# Altium schematic sheet 04_NOTECARD-CONNECTION.SchDoc
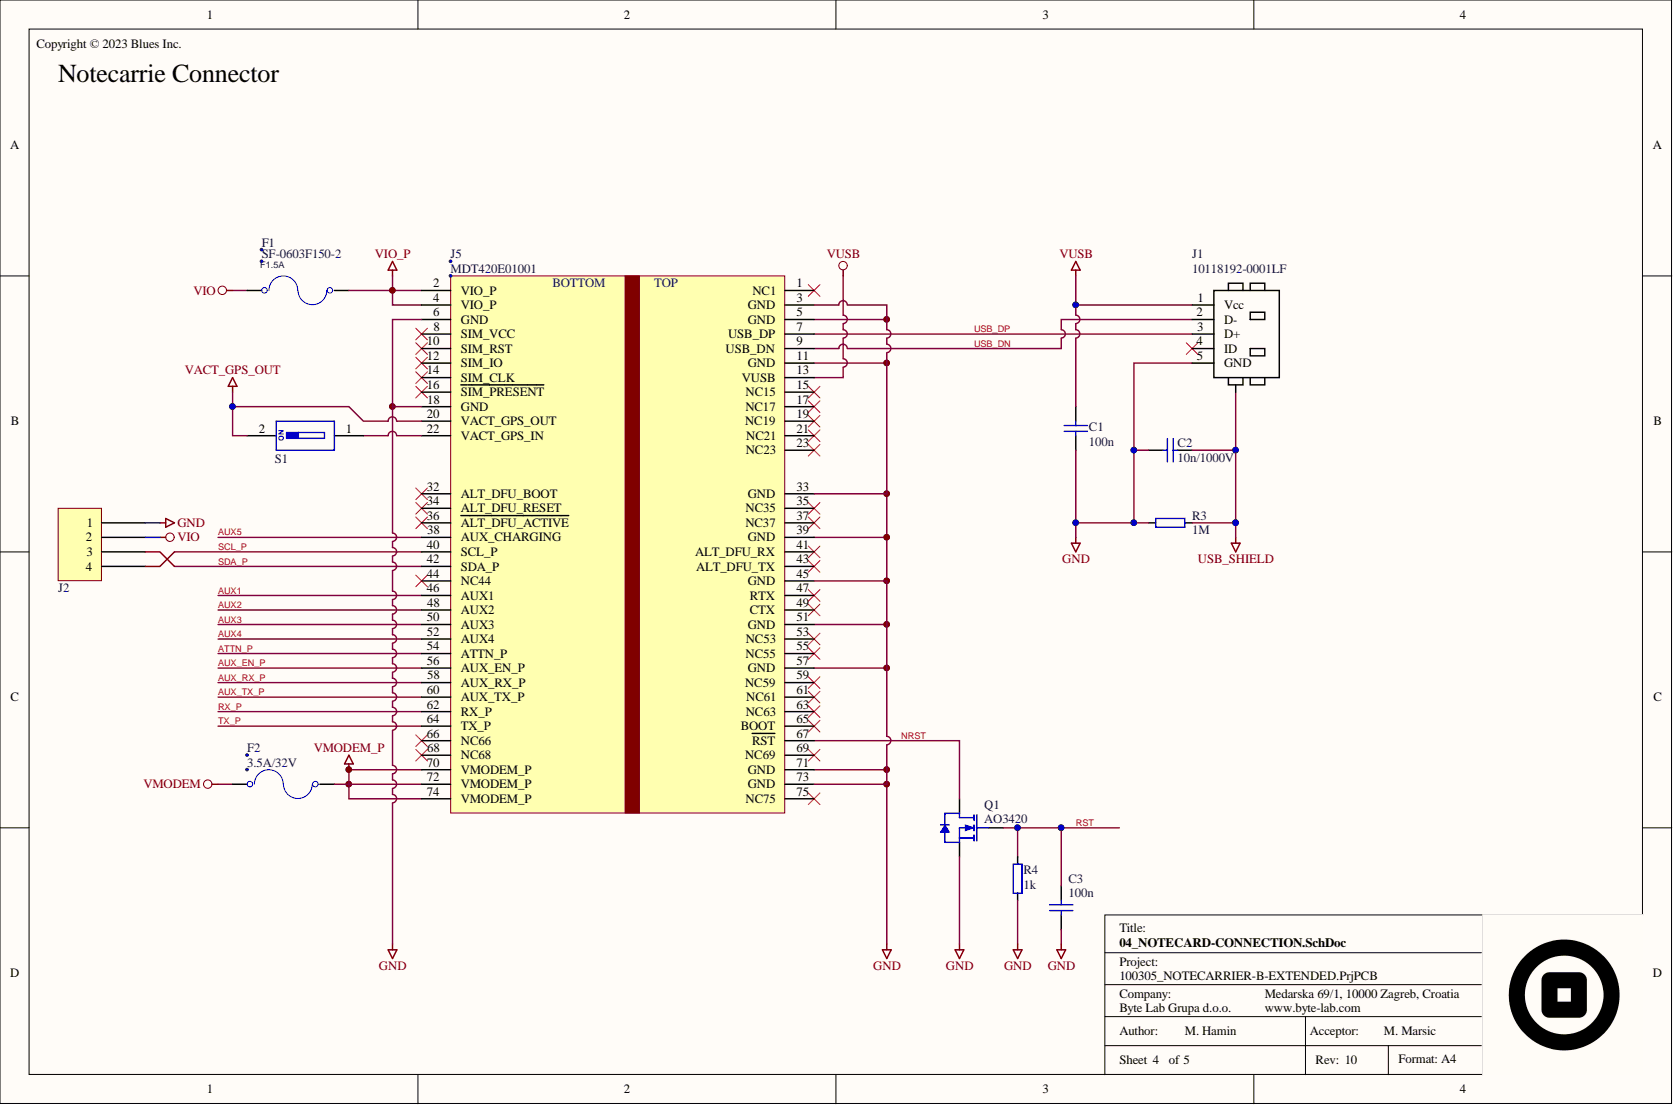
[diagram p0: 04_NOTECARD-CONNECTION.SchDoc]

## Parts
C1  100n  [C-0402]
C2  10n/1000V  [C-1206]
C3  100n  [C-0402]
F1  SF-0603F150-2  [FS-0603]
F2  3.5A/32V  [FS-0603]
J1  10118192-0001LF  [Conn_USB_B_Micro_-_10118192-0001LF]
J2  SM04B-SRSS-TB(LF)(SN)  [JST SM04B-SRSS-TB]  (value not drawn)
J5  MDT420E01001  [J-75-0050-MOS-M2]
Q1  AO3420  [SOT-23]
R3  1M  [R-1206]
R4  1k  [R-0402]
S1  CHS-01TB  [SWITCH DIP 1POS SMD CHS-01TB]  (value not drawn)

## Nets
GND: C1.2 C2.2 C3.1 J1.5(GND) J2.1 J5.3(GND) J5.5(GND) J5.6(GND) J5.11(GND) J5.18(GND) J5.33(GND) J5.39(GND) J5.45(GND) J5.51(GND) J5.57(GND) J5.71(GND) J5.73(GND) Q1.2 R3.2 R4.1
USB_SHIELD: C2.1 J1.M R3.1
VACT_GPS_OUT: J5.20(VACT_GPS_OUT) S1.2
VIO: F1.1 J2.2
VIO_P: F1.2 J5.2(VIO_P) J5.4(VIO_P)
VMODEM: F2.1
VMODEM_P: F2.2 J5.70(VMODEM_P) J5.72(VMODEM_P) J5.74(VMODEM_P)
VUSB: C1.1 J1.1(Vcc) J5.13(VUSB)
ATTN_P: J5.54(ATTN_P)
AUX1: J5.46(AUX1)
AUX2: J5.48(AUX2)
AUX3: J5.50(AUX3)
AUX4: J5.52(AUX4)
AUX5: J5.38(AUX_CHARGING)
AUX_EN_P: J5.56(AUX_EN_P)
AUX_RX_P: J5.58(AUX_RX_P)
AUX_TX_P: J5.60(AUX_TX_P)
NRST: J5.67(RST) Q1.3
RST: C3.2 Q1.1 R4.2
RX_P: J5.62(RX_P)
SCL_P: J2.4 J5.40(SCL_P)
SDA_P: J2.3 J5.42(SDA_P)
TX_P: J5.64(TX_P)
USB_DN: J1.2(D-) J5.9(USB_DN)
USB_DP: J1.3(D+) J5.7(USB_DP)
NetJ5_22: J5.22(VACT_GPS_IN) S1.1

Not connected: J1 4(ID); J5 1(NC1) 8(SIM_VCC) 10(SIM_RST) 12(SIM_IO) 14(SIM_CLK) 15(NC15) 16(SIM_PRESENT) 17(NC17) 19(NC19) 21(NC21) 23(NC23) 32(ALT_DFU_BOOT) 34(ALT_DFU_RESET) 35(NC35) 36(ALT_DFU_ACTIVE) 37(NC37) 41(ALT_DFU_RX) 43(ALT_DFU_TX) 44(NC44) 47(RTX) 49(CTX) 53(NC53) 55(NC55) 59(NC59) 61(NC61) 63(NC63) 65(BOOT) 66(NC66) 68(NC68) 69(NC69) 75(NC75)

## Library data of the parts
C1 C3 = C0402-100n-16V-X7R: Capacitor ceramic 100n ±10% X7R 16V 0402; BL Part Number: 2000-908; Checked_By: Dodig; Drawn_By: Puskar; Component Kind: Standard; DateModified: 27-08-2013; Library Name: BL_Passive.SchLib; Manufacturer: N/A; Manufacturer Part Number: N/A; Simulation: CAP; Supplier 1: Digi-Key; Supplier Part Number 1: 490-3261-1-ND
C2 = C1206-10n-1000V-X7R - CS1206KKX7RCBB103: Capacitor ceramic 10n ±10% X7R 1000V 1206; BL Part Number: 2007-449; Manufacturer: YAGEO; Drawn_By: Hamin; Component Kind: Standard; DateModified: 03-05-2023; Library Name: BL_Passive.SchLib; Manufacturer Part Number: CS1206KKX7RCBB103; Supplier 1: Digi-Key; Supplier Part Number 1: 311-3578-1-ND
F1 = RAPIDO - SF-0603F150-2-1.5A/32V: FUSIBILE RAPIDO 1.5A 32V 0603 SF-0603F150-2 BOURNS SMT; BL Part Number: 2007-468; Manufacturer: Bourns Inc.; Drawn_By: Blues Wireless; Component Kind: Standard; Library Name: n21-p2.SchLib; Manufacturer Part Number: SF-0603F150-2; Supplier 1: Digi-Key; Supplier Part Number 1: SF-0603F150-2CT-ND
F2 = RAPIDO - 0603SFV350F- 3.5A/32V: FUSIBILE RAPIDO 3.5A 32V 0603 0603SFV350F/32-2 LITTELFUSE SMT; BL Part Number: 2007-664; Manufacturer: Littelfuse Inc.; Drawn_By: Blues Wireless; Component Kind: Standard; Library Name: n21-p2.SchLib; Manufacturer Part Number: 0603SFV350F/32-2; Supplier 1: Digi-Key; Supplier Part Number 1: 0603SFV350F/32-2CT-ND
J1 = Conn USB B Micro - 10118192-0001LF: Connector; Micro B , SMD; 5 Position; Right Angle; BL Part Number: 2002-715; Manufacturer: FCI; Checked_By: Zvonc; Drawn_By: Puskar; Component Kind: Standard; DateModified: 25-03-2015; Library Name: BL_Mechanical.SchLib; Manufacturer Part Number: 10118192-0001LF; Supplier 1: Digi-Key; Supplier Part Number 1: 609-4613-1-ND
J2 = SM04B-SRSS-TB(LF)(SN): CONN HEADER SH 4POS SIDE 1MM TIN; BL Part Number: 2002-058; Manufacturer: JST Sales America Inc.; Drawn_By: Blues Wireless; Component Kind: Standard; Library Name: n21-p2.SchLib; Manufacturer Part Number: SM04B-SRSS-TB(LF)(SN); Supplier 1: Digi-Key; Supplier Part Number 1: 455-1804-1-ND
J5 = AMPHENOL-75 - M-2 KEY E_13: CONN. M.2 75P M/90° P=0.5 MDT420E01001 AMPHENOL SMT; BL Part Number: 2007-460; Manufacturer: Amphenol ICC (FCI); Manufacturer Part Number: MDT420E03001; Drawn_By: Blues Wireless; Component Kind: Standard; Library Name: n21-p2.SchLib; Supplier 1: Digi-Key; Supplier Part Number 1: MDT420E03001CT-ND
Q1 = AO3420: MOSFET, N-CH, 20V, 6A, SOT-23; BL Part Number: 2007-663; Manufacturer: Alpha & Omega Semiconductor Inc.; Drawn_By: Hamin; Component Kind: Standard; DateModified: 19-06-23; Library Name: BL_Active.SchLib; Manufacturer Part Number: AO3420; Supplier 1: Digi-Key; Supplier Part Number 1: 785-1014-1-ND
R3 = R1206-1M-1: Resistor 1M ±1% 1206; BL Part Number: 2001-438; Checked_By: Golubic; Drawn_By: Zvonc; Component Kind: Standard; DateModified: 01-07-2014; Library Name: BL_Passive.SchLib; Manufacturer: N/A; Manufacturer Part Number: N/A
R4 = R0402-1k-1: Resistor 1k ±1% 0402; BL Part Number: 2000-694; Checked_By: Zvonc; Drawn_By: Dodig; Component Kind: Standard; DateModified: 17-02-2016; Library Name: BL_Passive.SchLib; Manufacturer: N/A; Manufacturer Part Number: N/A; Supplier 1: Digi-Key; Supplier Part Number 1: 311-1.00KLRCT-ND
S1 = Switch DIP 1POS CHS-01TB: DIP Switch 1POS SMD 6V; BL Part Number: 2007-659; Manufacturer: Nidec Components Corporation; Checked_By: Marsic; Drawn_By: Hamin; Component Kind: Standard; DateModified: 02-01-2024; Library Name: BL_Mechanical.SchLib; Manufacturer Part Number: CHS-01TB; Supplier 1: Digi-Key; Supplier Part Number 1: 563-1004-1-ND
